# Altium schematic sheet NRF_IoT_Dongle.SchDoc
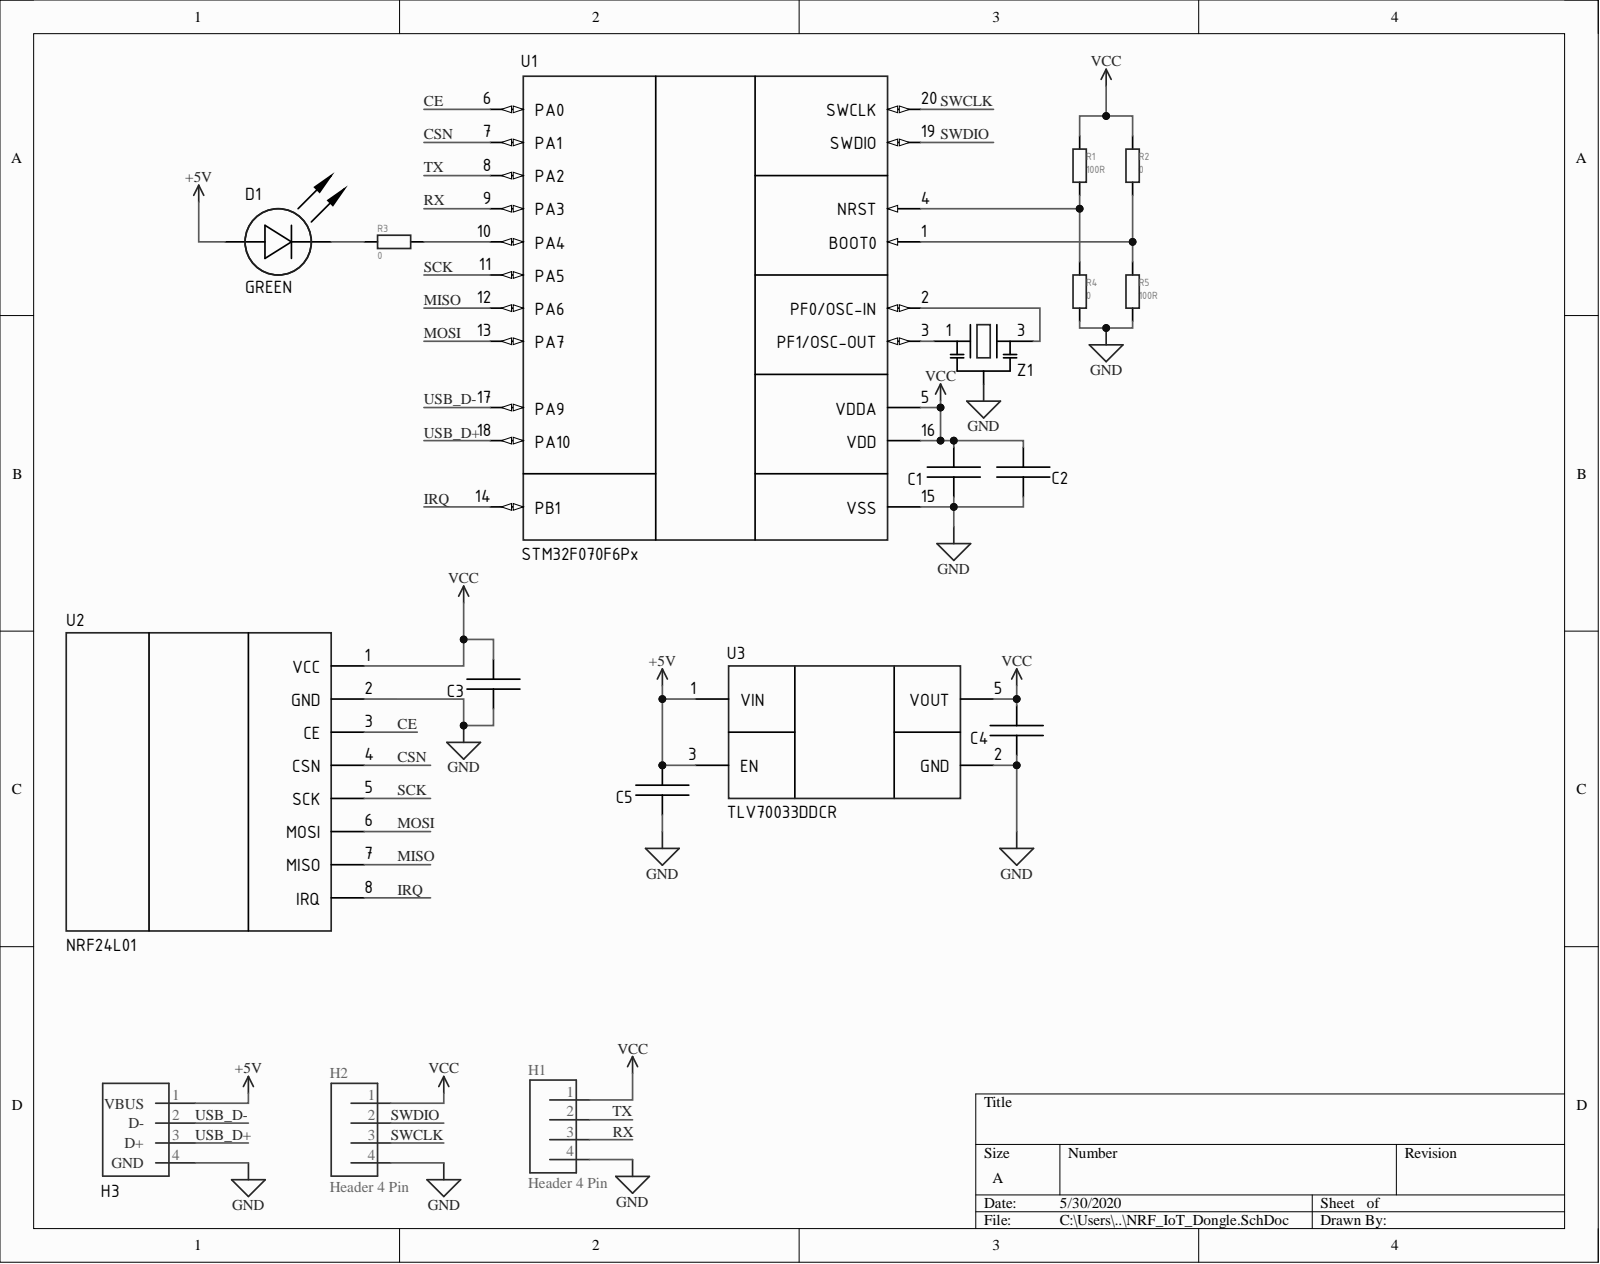
[diagram p0: NRF_IoT_Dongle.SchDoc]

## Parts
C1  Ceramic capacitor, 0.01μF, X7R, ±10%, 16V, 0201, -55...+125°C  [CAP: 0402]  (value not drawn)
C2  Ceramic capacitor, 0.01μF, X7R, ±10%, 16V, 0201, -55...+125°C  [CAP: 0402]  (value not drawn)
C3  Ceramic capacitor, 0.01μF, X7R, ±10%, 16V, 0201, -55...+125°C  [CAP: 0402]  (value not drawn)
C4  Ceramic capacitor, 0.01μF, X7R, ±10%, 16V, 0201, -55...+125°C  [CAP: 0402]  (value not drawn)
C5  Ceramic capacitor, 0.01μF, X7R, ±10%, 16V, 0201, -55...+125°C  [CAP: 0402]  (value not drawn)
D1  Color: GREEN  [LED: 0402B]  (hidden comment: LED, Green, *, *, *, -55...+150°C)
H1  Header 4 Pin  [04: jst_sh_side]
H2  Header 4 Pin  [04: jst_sh_side]
H3  USB mini B  [USB_A_PCB]  (value not drawn)
R1  value 100R  [RES: 0402]  (hidden comment: Resistor film, 100R, ±5%, , 0.05W, -55...+125°C)
R2  value 0  [RES: 0402]  (hidden comment: Resistor film, 0, ±5%, , 0.05W, -55...+125°C)
R3  value 0  [RES: 0402]  (hidden comment: Resistor film, 0, ±5%, , 0.05W, -55...+125°C)
R4  value 0  [RES: 0402]  (hidden comment: Resistor film, 0, ±5%, , 0.05W, -55...+125°C)
R5  value 100R  [RES: 0402]  (hidden comment: Resistor film, 100R, ±5%, , 0.05W, -55...+125°C)
U1  IC microcontroller, 32-Bit, Cortex-M0, 48MHz, 16KB, 4KB, 2.4...3.6V, -40...+85°C, 20-TSSOP  [20-TSSOP]  (value not drawn)
U2  RF module, ShockBurst™, 32 bit, SPI, -40...+85°C, 21x16mm  [MOD: NRF24L01]  (value not drawn)
U3  Linear voltage regulator, input 5.5V, 0.25V, output 3.3V, 200 mA, -40...+85°C, SOT-23-5  [SOT23-5]  (value not drawn)
Z1  Crystal, , 12.5pF, ±20ppm, case 3215, -40...+85°C  [OSC: CSTCE]  (value not drawn)

## Nets
+5V: C5.2 D1.1 H3.1(VBUS) U3.1(VIN) U3.3(EN)
GND: C1.1 C2.1 C3.1 C4.1 C5.1 H1.4 H2.4 H3.4(GND) R4.2 R5.2 U1.15(VSS) U2.2(GND) U3.2(GND) Z1.2
VCC: C1.2 C2.2 C3.2 C4.2 H1.1 H2.1 R1.2 R2.1 U1.5(VDDA) U1.16(VDD) U2.1(VCC) U3.5(VOUT)
CE: U1.6(PA0) U2.3(CE)
CSN: U1.7(PA1) U2.4(CSN)
IRQ: U1.14(PB1) U2.8(IRQ)
MISO: U1.12(PA6) U2.7(MISO)
MOSI: U1.13(PA7) U2.6(MOSI)
RX: H1.3 U1.9(PA3)
SCK: U1.11(PA5) U2.5(SCK)
SWCLK: H2.3 U1.20(SWCLK)
SWDIO: H2.2 U1.19(SWDIO)
TX: H1.2 U1.8(PA2)
USB_D+: H3.3(D+) U1.18(PA10)
USB_D-: H3.2(D-) U1.17(PA9)
NetD1_2: D1.2 R3.1
NetR1_1: R1.1 R4.1 U1.4(NRST)
NetR2_2: R2.2 R5.1 U1.1(BOOT0)
NetR3_2: R3.2 U1.10(PA4)
NetU1_2: U1.2(PF0/OSC-IN) Z1.3
NetU1_3: U1.3(PF1/OSC-OUT) Z1.1

## Library data of the parts
C1-C5 = Ceramic: Manufacturer: Yageo; PartNumber: CC0201KRX7R7BB103; Component Kind: Standard; HelpURL: https://www.digikey.com/product-detail/en/yageo/CC0201KRX7R7BB103/311-1404-1-ND/2833710; Library Name: Capacitor.IntLib; Package: 0201; Temperature: -55...+125°C; Tolerance: ±10%; TypeCapacitor: Ceramic capacitor; TypeDielectric: X7R; Value: 0.01μF; ValueManufacturerPartNumber: Ceramic capacitor, 0.01μF, X7R, ±10%, 16V, 0201, -55...+125°C; Voltage: 16V
D1 = LED_single: ColorParameter: Green; Component Kind: Standard; Library Name: Diode.IntLib; Temperature: -55...+150°C; TypeDiode: LED; ValueManufacturerPartNumber: LED, Green, *, *, *, -55...+150°C
H1 H2 = 04: Component Kind: Standard; Library Name: Connector.IntLib
H3 = USB_A: Manufacturer: Molex; PartNumber: 0675031020; Component Kind: Standard; HelpURL: https://www.digikey.com/product-detail/en/molex/0675031020/WM5461CT-ND/1624427; Library Name: Connector.IntLib; TypeConnector: USB mini B; ValueManufacturerPartNumber: USB mini B
R1-R5 = Resistor: Manufacturer: Yageo; PartNumber: RC0201JR-07100RL; Component Kind: Standard; HelpURL: https://www.digikey.com/product-detail/en/yageo/RC0201JR-07100RL/311-100NCT-ND/1949006; Library Name: Resistor.IntLib; Power: 0.05W; Temperature: -55...+125°C; Tolerance: ±5%; TypeResistor: Resistor film
  R1 R5: ValueManufacturerPartNumber: Resistor film, 100R, ±5%, , 0.05W, -55...+125°C
  R2-R4: ValueManufacturerPartNumber: Resistor film, 0, ±5%, , 0.05W, -55...+125°C
U1 = STM32F070F6Px: Cortex-M0; Manufacturer: STMicroelectronics; Component Kind: Standard; ComponentType: IC microcontroller; CoreProcessor: Cortex-M0; CoreSize: 32-Bit; HelpURL: https://www.digikey.com/product-detail/en/stmicroelectronics/STM32F030F4P6TR/497-17333-1-ND/7650385; Library Name: IC. MCU.IntLib; MemoryRAM: 4KB; MemoryROM: 16KB; Package: 20-TSSOP; PartNumber: STM32F070F6Px; Speed: 48MHz; Supply: 2.4...3.6V; Temperature: -40...+85°C; ValueManufacturerPartNumber: IC microcontroller, 32-Bit, Cortex-M0, 48MHz, 16KB, 4KB, 2.4...3.6V, -40...+85°C, 20-TSSOP
U2 = NRF24L01: RF module; Manufacturer: Nordic Semiconductor; Core: 32 bit; Component Kind: Standard; ComponentType: RF module; HelpURL: https://www.sparkfun.com/datasheets/Components/nRF24L01_prelim_prod_spec_1_2.pdf; Library Name: Module.IntLib; Package: 21x16mm; PartNumber: NRF24L01; Temperature: -40...+85°C; TypeInterface: SPI; TypeStandart: ShockBurst™; ValueManufacturerPartNumber: RF module, ShockBurst™, 32 bit, SPI, -40...+85°C, 21x16mm
U3 = TLV70033DDCR: Linear voltage regulator; Manufacturer: Texas Instruments; CurrentOutput: 200 mA; Component Kind: Standard; ComponentType: Linear voltage regulator; HelpURL: https://www.digikey.com/product-detail/en/texas-instruments/TLV70033DDCR/296-27937-1-ND/2440840; Library Name: IC. Power supply.IntLib; MaxDropout: 0.25V; Package: SOT-23-5; PartNumber: TLV70033DDCR; Temperature: -40...+85°C; ValueManufacturerPartNumber: Linear voltage regulator, input 5.5V, 0.25V, output 3.3V, 200 mA, -40...+85°C, SOT-23-5; VoltageInput: input 5.5V; VoltageOutput: output 3.3V
Z1 = CSTNE: Manufacturer: Epson; PartNumber: FC-135 32.7680KA-A5; Component Kind: Standard; HelpURL: https://www.digikey.com/product-detail/en/epson/FC-135-32.7680KA-A5/SER4103CT-ND/6188447; Library Name: Oscilator.IntLib; LoadCapacitance: 12.5pF; Package: case 3215; Temperature: -40...+85°C; Tolerance: ±20ppm; TypeOscilator: Crystal; ValueManufacturerPartNumber: Crystal, , 12.5pF, ±20ppm, case 3215, -40...+85°C
